# Altium schematic sheet Motorshield_ESP32_V4_schematic_outputjob.SchDoc
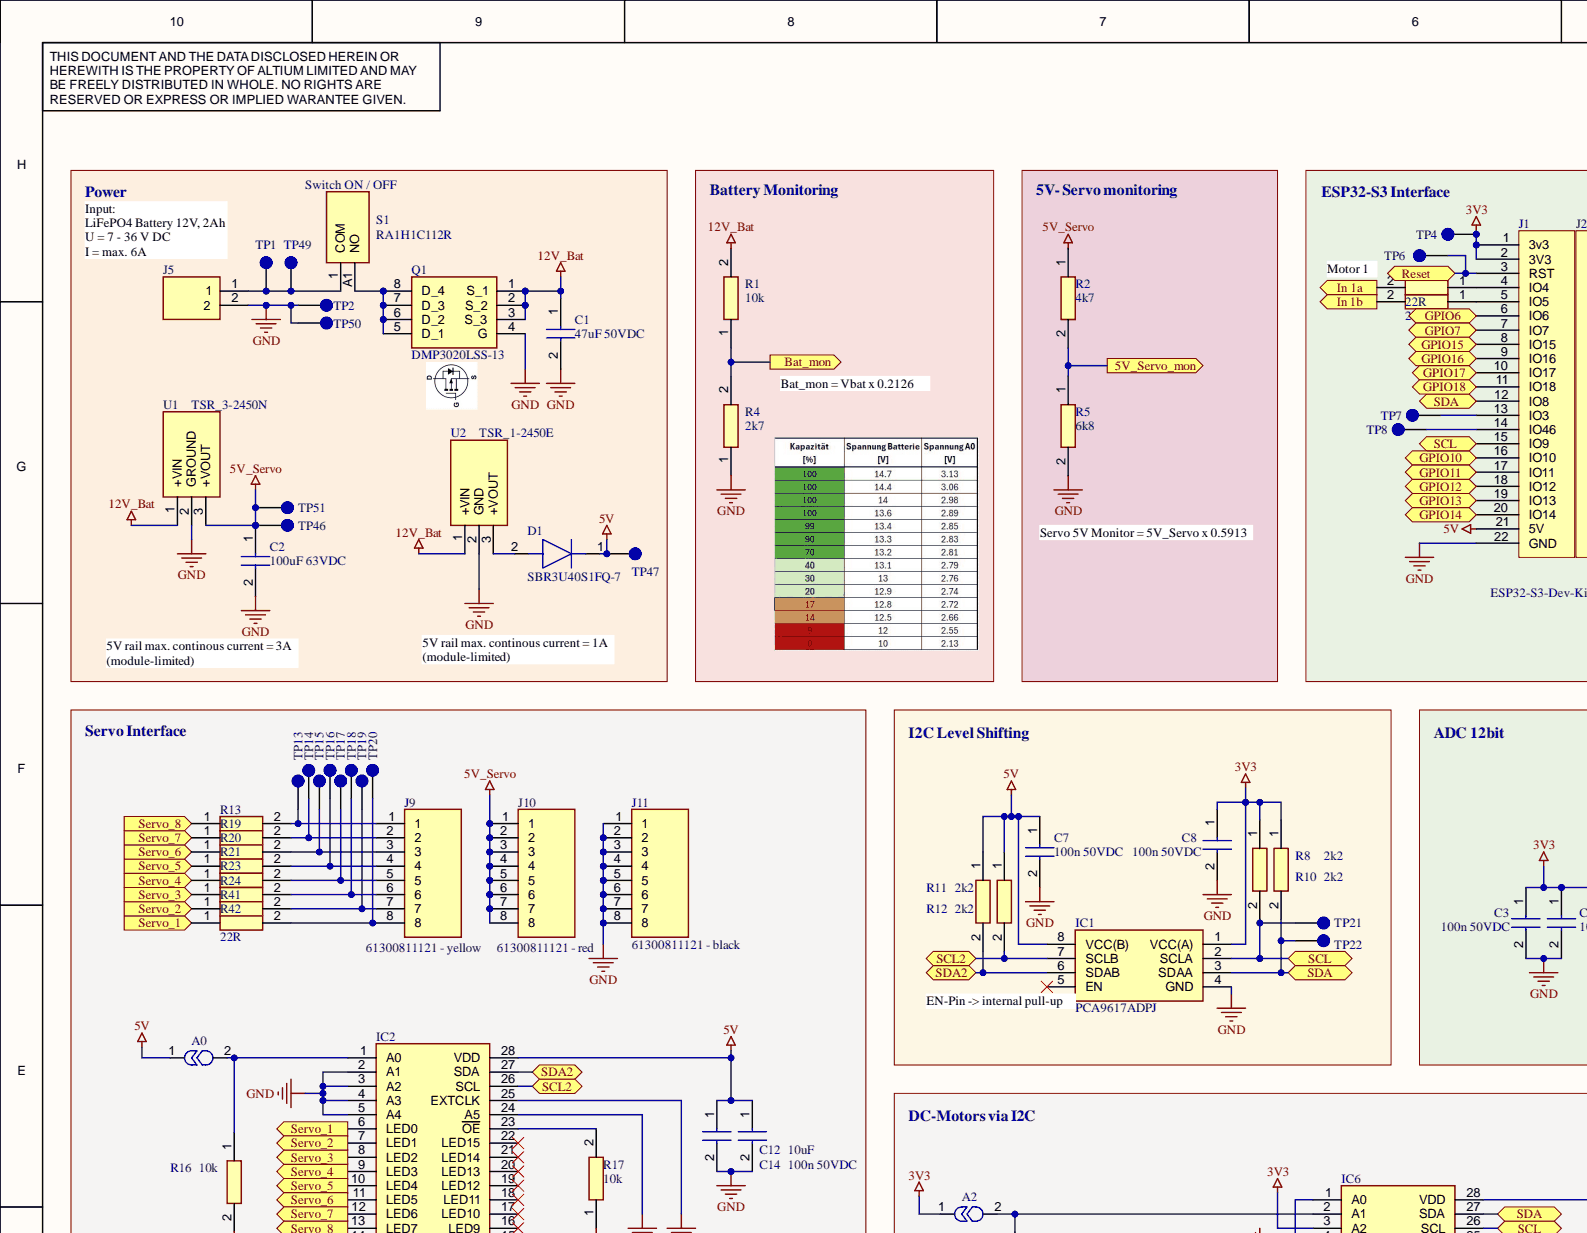
[diagram p0: Motorshield_ESP32_V4_schematic_outputjob.SchDoc, top left]
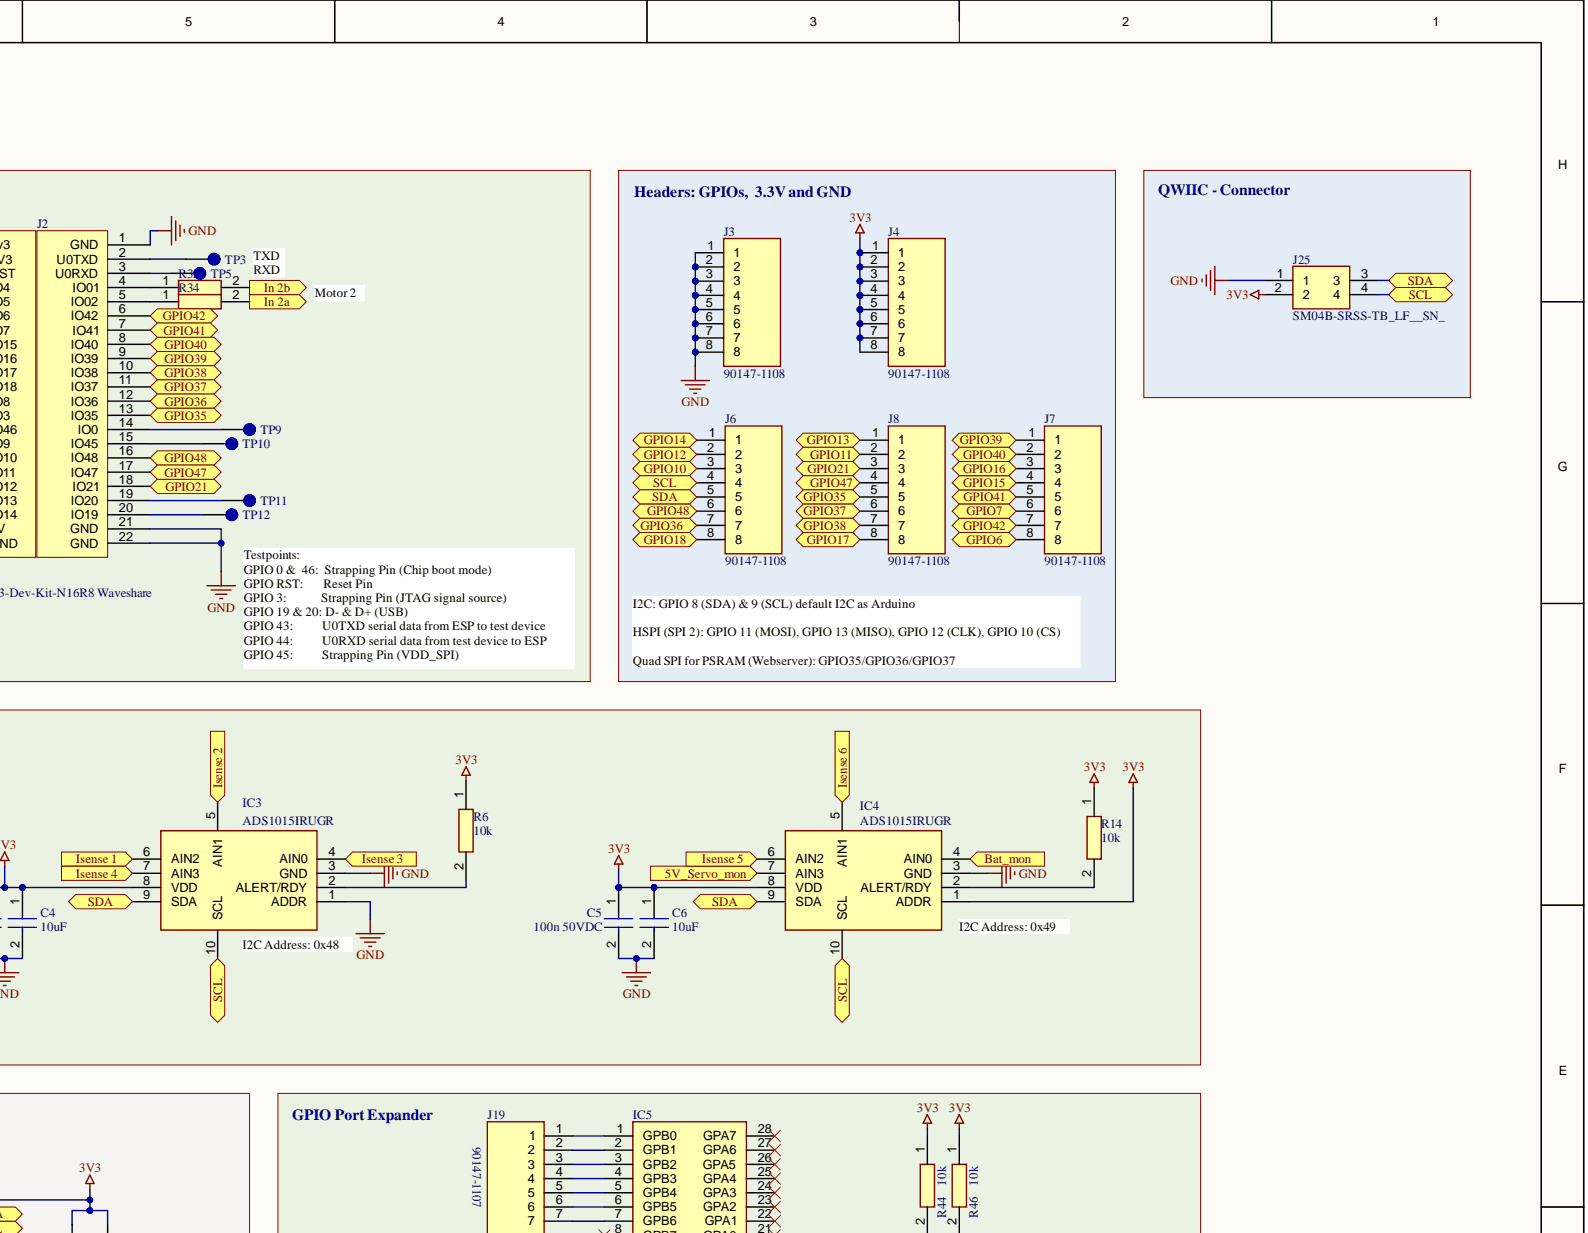
[diagram p1: Motorshield_ESP32_V4_schematic_outputjob.SchDoc, top right]
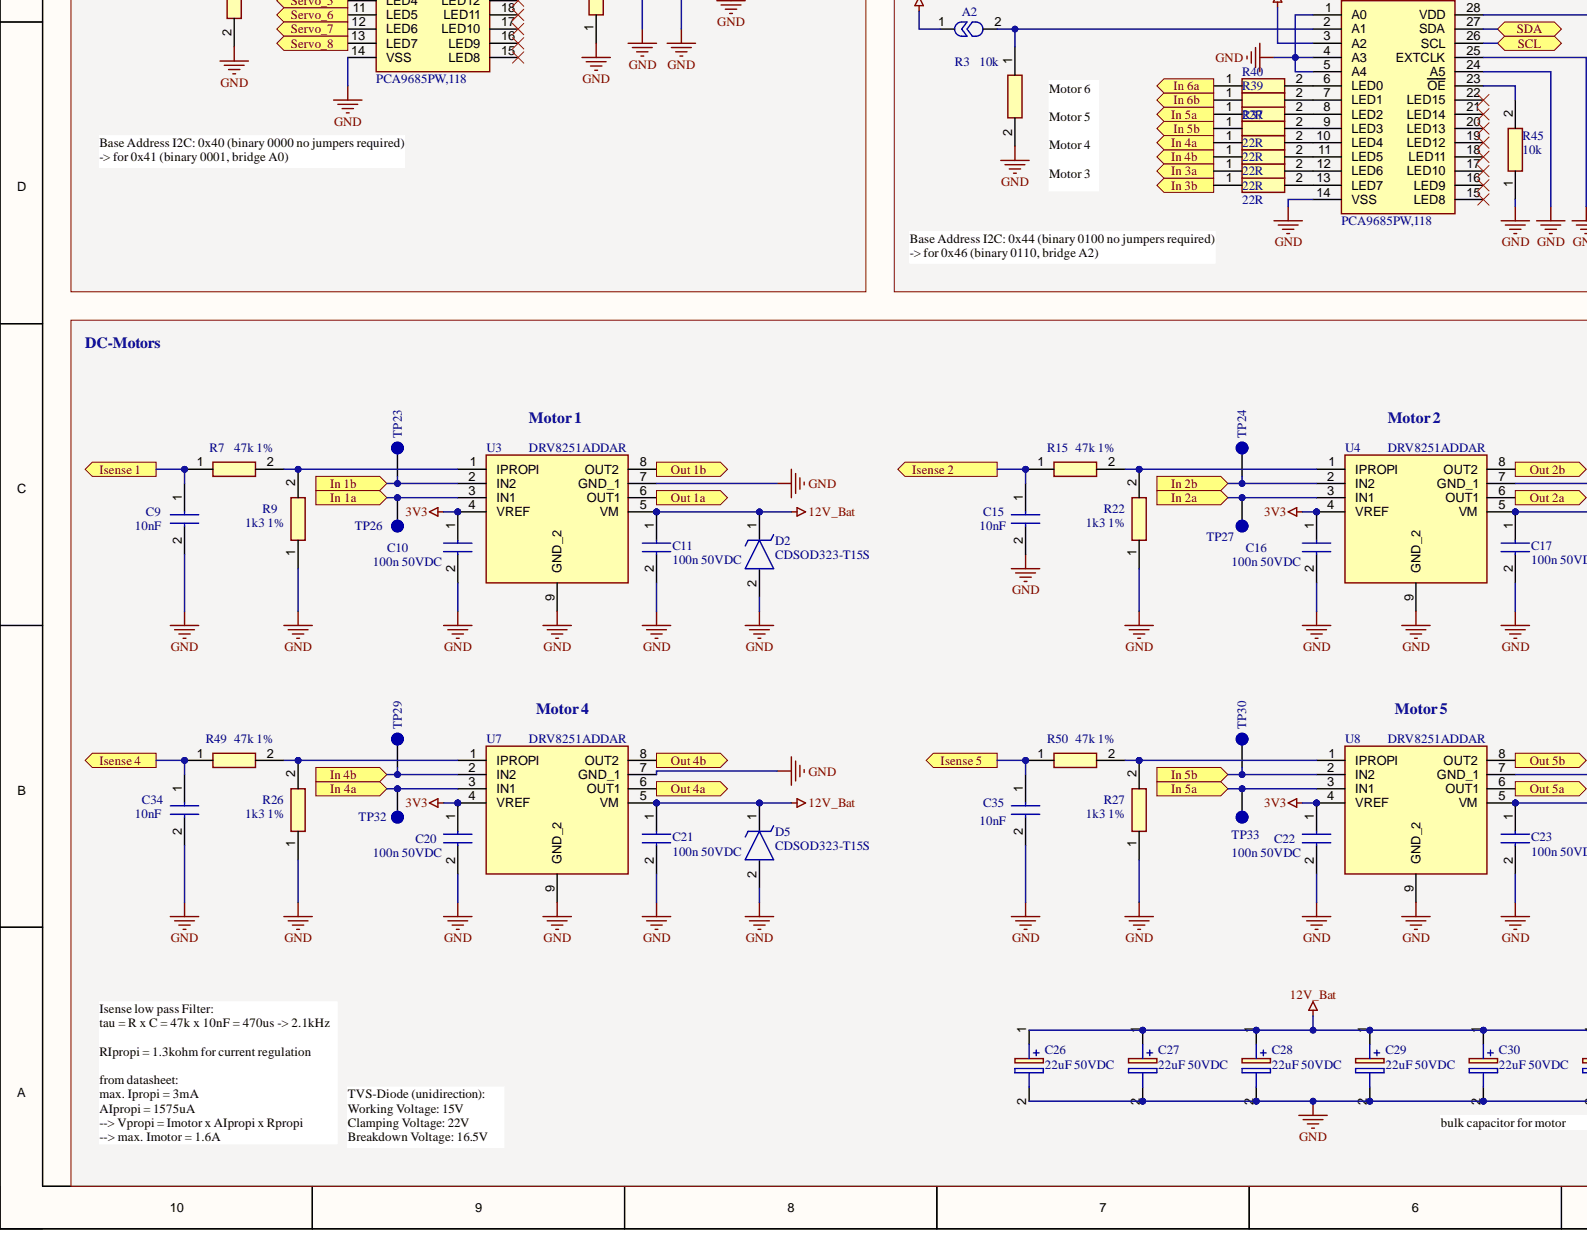
[diagram p2: Motorshield_ESP32_V4_schematic_outputjob.SchDoc, bottom left]
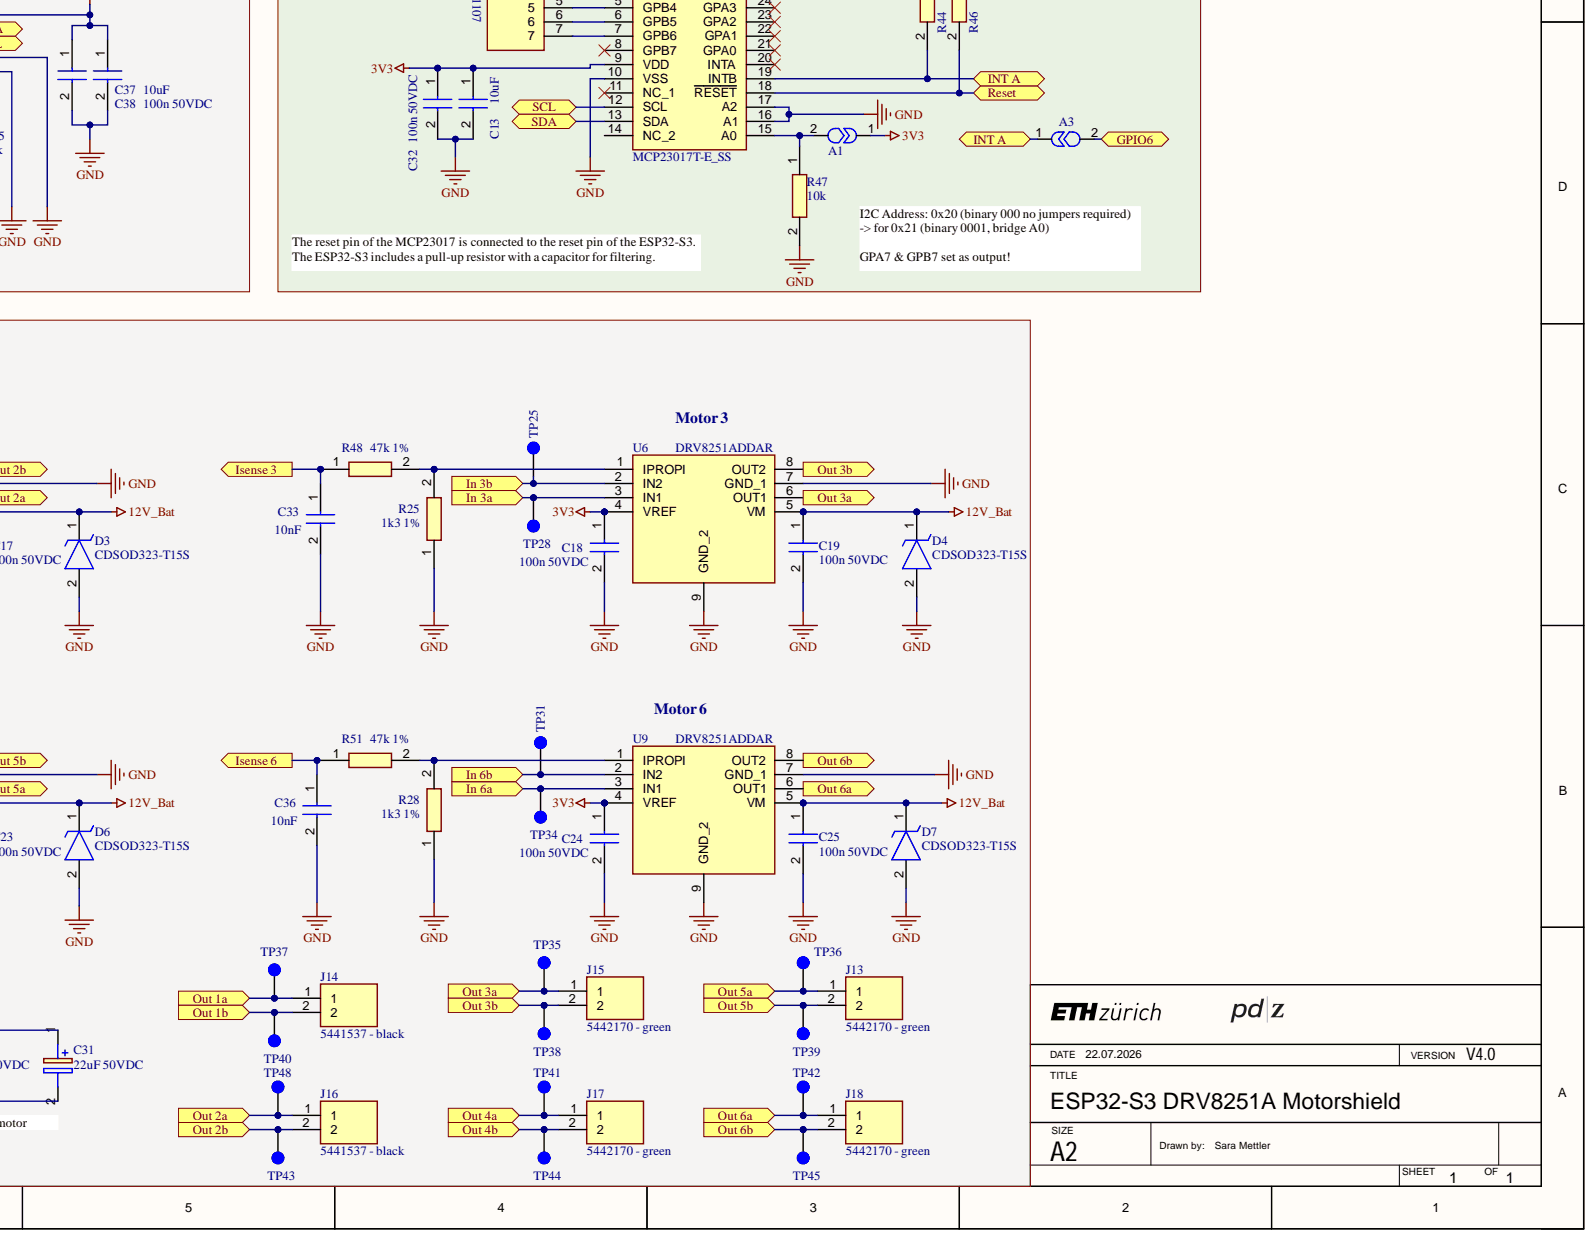
[diagram p3: Motorshield_ESP32_V4_schematic_outputjob.SchDoc, bottom right]

## Parts
A0  Solder Jumper  [JUMP_SOLDER_JUMPER_1206]  (value not drawn)
A1  Solder Jumper  [JUMP_SOLDER_JUMPER_1206]  (value not drawn)
A2  Solder Jumper  [JUMP_SOLDER_JUMPER_1206]  (value not drawn)
A3  Solder Jumper  [JUMP_SOLDER_JUMPER_1206]  (value not drawn)
C1  47uF 50VDC  [CAPAE660X980N]
C2  100uF 63VDC  [CAPAE830X1000N]
C3  100n 50VDC  [CAPC1608X90N]
C4  10uF  [GRM21BR60J226ME39L]
C5  100n 50VDC  [CAPC1608X90N]
C6  10uF  [GRM21BR60J226ME39L]
C7  100n 50VDC  [CAPC1608X90N]
C8  100n 50VDC  [CAPC1608X90N]
C9  10nF  [CAPC1608X90N]
C10  100n 50VDC  [CAPC1608X90N]
C11  100n 50VDC  [CAPC1608X90N]
C12  10uF  [GRM21BR60J226ME39L]
C13  10uF  [GRM21BR60J226ME39L]
C14  100n 50VDC  [CAPC1608X90N]
C15  10nF  [CAPC1608X90N]
C16  100n 50VDC  [CAPC1608X90N]
C17  100n 50VDC  [CAPC1608X90N]
C18  100n 50VDC  [CAPC1608X90N]
C19  100n 50VDC  [CAPC1608X90N]
C20  100n 50VDC  [CAPC1608X90N]
C21  100n 50VDC  [CAPC1608X90N]
C22  100n 50VDC  [CAPC1608X90N]
C23  100n 50VDC  [CAPC1608X90N]
C24  100n 50VDC  [CAPC1608X90N]
C25  100n 50VDC  [CAPC1608X90N]
C26  22uF 50VDC  [EEHZA1E101XP]
C27  22uF 50VDC  [EEHZA1E101XP]
C28  22uF 50VDC  [EEHZA1E101XP]
C29  22uF 50VDC  [EEHZA1E101XP]
C30  22uF 50VDC  [EEHZA1E101XP]
C31  22uF 50VDC  [EEHZA1E101XP]
C32  100n 50VDC  [CAPC1608X90N]
C33  10nF  [CAPC1608X90N]
C34  10nF  [CAPC1608X90N]
C35  10nF  [CAPC1608X90N]
C36  10nF  [CAPC1608X90N]
C37  10uF  [GRM21BR60J226ME39L]
C38  100n 50VDC  [CAPC1608X90N]
D1  SBR3U40S1FQ-7  [SODFL3518X115N]
D2  CDSOD323-T15S  [SOD2513X114N]
D3  CDSOD323-T15S  [SOD2513X114N]
D4  CDSOD323-T15S  [SOD2513X114N]
D5  CDSOD323-T15S  [SOD2513X114N]
D6  CDSOD323-T15S  [SOD2513X114N]
D7  CDSOD323-T15S  [SOD2513X114N]
IC1  PCA9617ADPJ  [SOP65P490X110-8N]
IC2  PCA9685PW,118  [SOP65P640X110-28N]
IC3  ADS1015IRUGR  [ADS1015IRUGR]
IC4  ADS1015IRUGR  [ADS1015IRUGR]
IC5  MCP23017T-E_SS  [SOP65P780X200-28N]
IC6  PCA9685PW,118  [SOP65P640X110-28N]
J1  Header links ESP32-S3  [CES12202SS]  (value not drawn)
J2  Header rechts ESP32-S3_1  [CES12202SS]  (value not drawn)
J3  90147-1108  [RHDR8W70P0X254_1X8_2017X244X870P]
J4  90147-1108  [RHDR8W70P0X254_1X8_2017X244X870P]
J5  691101710002 - blue  [RHDRRA2W95P0X500_1X2_1120X900X1260P]  (value not drawn)
J6  90147-1108  [RHDR8W70P0X254_1X8_2017X244X870P]
J7  90147-1108  [RHDR8W70P0X254_1X8_2017X244X870P]
J8  90147-1108  [RHDR8W70P0X254_1X8_2017X244X870P]
J9  61300811121 - yellow  [HDRV8W64P0X254_1X8_2032X254X854P]
J10  61300811121 - red  [HDRV8W64P0X254_1X8_2032X254X854P]
J11  61300811121 - black  [HDRV8W64P0X254_1X8_2032X254X854P]
J13  5442170 - green  [5442170]
J14  5441537 - black  [5441537]
J15  5442170 - green  [5442170]
J16  5441537 - black  [5441537]
J17  5442170 - green  [5442170]
J18  5442170 - green  [5442170]
J19  90147-1107  [SHDR7W65P0X254_1X7_2024X510X1180P]
J25  SM04B-SRSS-TB_LF__SN_  [SM04B-SRSS-TB(LFSN)]
Q1  DMP3020LSS-13  [SOIC127P600X175-8N]
R1  10k  [RESC1608X55N]
R2  4k7  [RESC1608X55N]
R3  10k  [RESC1608X55N]
R4  2k7  [RESC1608X55N]
R5  6k8  [RESC1608X55N]
R6  10k  [RESC1608X55N]
R7  47k 1%  [RESC1608X55N]
R8  2k2  [RESC1608X55N]
R9  1k3 1%  [RESC1608X55N]
R10  2k2  [RESC1608X55N]
R11  2k2  [RESC1608X55N]
R12  2k2  [RESC1608X55N]
R13  22R  [RESC1608X55N]
R14  10k  [RESC1608X55N]
R15  47k 1%  [RESC1608X55N]
R16  10k  [RESC1608X55N]
R17  10k  [RESC1608X55N]
R19  22R  [RESC1608X55N]
R20  22R  [RESC1608X55N]
R21  22R  [RESC1608X55N]
R22  1k3 1%  [RESC1608X55N]
R23  22R  [RESC1608X55N]
R24  22R  [RESC1608X55N]
R25  1k3 1%  [RESC1608X55N]
R26  1k3 1%  [RESC1608X55N]
R27  1k3 1%  [RESC1608X55N]
R28  1k3 1%  [RESC1608X55N]
R29  22R  [RESC1608X55N]
R30  22R  [RESC1608X55N]
R31  22R  [RESC1608X55N]
R32  22R  [RESC1608X55N]
R33  22R  [RESC1608X55N]
R34  22R  [RESC1608X55N]
R35  22R  [RESC1608X55N]
R36  22R  [RESC1608X55N]
R37  22R  [RESC1608X55N]
R38  22R  [RESC1608X55N]
R39  22R  [RESC1608X55N]
R40  22R  [RESC1608X55N]
R41  22R  [RESC1608X55N]
R42  22R  [RESC1608X55N]
R44  10k  [RESC1608X55N]
R45  10k  [RESC1608X55N]
R46  10k  [RESC1608X55N]
R47  10k  [RESC1608X55N]
R48  47k 1%  [RESC1608X55N]
R49  47k 1%  [RESC1608X55N]
R50  47k 1%  [RESC1608X55N]
R51  47k 1%  [RESC1608X55N]
S1  RA1H1C112R  [RA1H1C112R]
TP1  837-22-001-30-001101  [8372200130001101]  (value not drawn)
TP2  837-22-001-30-001101  [8372200130001101]  (value not drawn)
TP3  837-22-001-30-001101  [8372200130001101]  (value not drawn)
TP4  837-22-001-30-001101  [8372200130001101]  (value not drawn)
TP5  837-22-001-30-001101  [8372200130001101]  (value not drawn)
TP6  837-22-001-30-001101  [8372200130001101]  (value not drawn)
TP7  837-22-001-30-001101  [8372200130001101]  (value not drawn)
TP8  837-22-001-30-001101  [8372200130001101]  (value not drawn)
TP9  837-22-001-30-001101  [8372200130001101]  (value not drawn)
TP10  837-22-001-30-001101  [8372200130001101]  (value not drawn)
TP11  837-22-001-30-001101  [8372200130001101]  (value not drawn)
TP12  837-22-001-30-001101  [8372200130001101]  (value not drawn)
TP13  837-22-001-30-001101  [8372200130001101]  (value not drawn)
TP14  837-22-001-30-001101  [8372200130001101]  (value not drawn)
TP15  837-22-001-30-001101  [8372200130001101]  (value not drawn)
TP16  837-22-001-30-001101  [8372200130001101]  (value not drawn)
TP17  837-22-001-30-001101  [8372200130001101]  (value not drawn)
TP18  837-22-001-30-001101  [8372200130001101]  (value not drawn)
TP19  837-22-001-30-001101  [8372200130001101]  (value not drawn)
TP20  837-22-001-30-001101  [8372200130001101]  (value not drawn)
TP21  837-22-001-30-001101  [8372200130001101]  (value not drawn)
TP22  837-22-001-30-001101  [8372200130001101]  (value not drawn)
TP23  837-22-001-30-001101  [8372200130001101]  (value not drawn)
TP24  837-22-001-30-001101  [8372200130001101]  (value not drawn)
TP25  837-22-001-30-001101  [8372200130001101]  (value not drawn)
TP26  837-22-001-30-001101  [8372200130001101]  (value not drawn)
TP27  837-22-001-30-001101  [8372200130001101]  (value not drawn)
TP28  837-22-001-30-001101  [8372200130001101]  (value not drawn)
TP29  837-22-001-30-001101  [8372200130001101]  (value not drawn)
TP30  837-22-001-30-001101  [8372200130001101]  (value not drawn)
TP31  837-22-001-30-001101  [8372200130001101]  (value not drawn)
TP32  837-22-001-30-001101  [8372200130001101]  (value not drawn)
TP33  837-22-001-30-001101  [8372200130001101]  (value not drawn)
TP34  837-22-001-30-001101  [8372200130001101]  (value not drawn)
TP35  837-22-001-30-001101  [8372200130001101]  (value not drawn)
TP36  837-22-001-30-001101  [8372200130001101]  (value not drawn)
TP37  837-22-001-30-001101  [8372200130001101]  (value not drawn)
TP38  837-22-001-30-001101  [8372200130001101]  (value not drawn)
TP39  837-22-001-30-001101  [8372200130001101]  (value not drawn)
TP40  837-22-001-30-001101  [8372200130001101]  (value not drawn)
TP41  837-22-001-30-001101  [8372200130001101]  (value not drawn)
TP42  837-22-001-30-001101  [8372200130001101]  (value not drawn)
TP43  837-22-001-30-001101  [8372200130001101]  (value not drawn)
TP44  837-22-001-30-001101  [8372200130001101]  (value not drawn)
TP45  837-22-001-30-001101  [8372200130001101]  (value not drawn)
TP46  837-22-001-30-001101  [8372200130001101]  (value not drawn)
TP47  837-22-001-30-001101  [8372200130001101]  (value not drawn)
TP48  837-22-001-30-001101  [8372200130001101]  (value not drawn)
TP49  837-22-001-30-001101  [8372200130001101]  (value not drawn)
TP50  837-22-001-30-001101  [8372200130001101]  (value not drawn)
TP51  837-22-001-30-001101  [8372200130001101]  (value not drawn)
U1  TSR_3-2450N  [TSR32450N]
U2  TSR_1-2450E  [TSR12450E]
U3  DRV8251ADDAR  [SOIC127P600X170-9N]
U4  DRV8251ADDAR  [SOIC127P600X170-9N]
U6  DRV8251ADDAR  [SOIC127P600X170-9N]
U7  DRV8251ADDAR  [SOIC127P600X170-9N]
U8  DRV8251ADDAR  [SOIC127P600X170-9N]
U9  DRV8251ADDAR  [SOIC127P600X170-9N]

## Ports
5V_Servo_mon, Bat_mon, GPIO6, GPIO7, GPIO10, GPIO11, GPIO12, GPIO13, GPIO14, GPIO15, GPIO16, GPIO17, GPIO18, GPIO21, GPIO35, GPIO36, GPIO37, GPIO38, GPIO39, GPIO40, GPIO41, GPIO42, GPIO47, GPIO48, In 1a, In 1b, In 2a, In 2b, In 3a, In 3b, In 4a, In 4b, In 5a, In 5b, In 6a, In 6b, INT A, Isense 1, Isense 2, Isense 3, Isense 4, Isense 5, Isense 6, Out 1a, Out 1b, Out 2a, Out 2b, Out 3a, Out 3b, Out 4a, Out 4b, Out 5a, Out 5b, Out 6a, Out 6b, Reset, SCL, SCL2, SDA, SDA2, Servo_1, Servo_2, Servo_3, Servo_4, Servo_5, Servo_6, Servo_7, Servo_8

## Nets
3V3: A1.1 A2.1 C3.1 C4.1 C5.1 C6.1 C8.1 C10.1 C13.1 C16.1 C18.1 C20.1 C22.1 C24.1 C32.1 C37.1 C38.1 IC1.1(VCC(A)) IC3.8(VDD) IC4.1(ADDR) IC4.8(VDD) IC5.9(VDD) IC6.3(A2) IC6.28(VDD) J1.1(3v3) J1.2(3V3) J4.1 J4.2 J4.3 J4.4 J4.5 J4.6 J4.7 J4.8 J25.2 R6.1 R8.1 R10.1 R14.1 R44.1 R46.1 TP4.1 U3.4(VREF) U4.4(VREF) U6.4(VREF) U7.4(VREF) U8.4(VREF) U9.4(VREF)
5V: A0.1 C7.1 C12.1 C14.1 D1.1 IC1.8(VCC(B)) IC2.28(VDD) J1.21(5V) R11.1 R12.1 TP47.1
5V_Servo: C2.1 J10.1 J10.2 J10.3 J10.4 J10.5 J10.6 J10.7 J10.8 R2.1 TP46.1 TP51.1 U1.3
12V_Bat: C1.1 C11.1 C17.1 C19.1 C21.1 C23.1 C25.1 C26.1 C27.1 C28.1 C29.1 C30.1 C31.1 D2.1 D3.1 D4.1 D5.1 D6.1 D7.1 Q1.1(S_1) Q1.2(S_2) Q1.3(S_3) R1.2 U1.1 U2.1 U3.5(VM) U4.5(VM) U6.5(VM) U7.5(VM) U8.5(VM) U9.5(VM)
GND: C1.2 C2.2 C3.2 C4.2 C5.2 C6.2 C7.2 C8.2 C9.2 C10.2 C11.2 C12.2 C13.2 C14.2 C15.2 C16.2 C17.2 C18.2 C19.2 C20.2 C21.2 C22.2 C23.2 C24.2 C25.2 C26.2 C27.2 C28.2 C29.2 C30.2 C31.2 C32.2 C33.2 C34.2 C35.2 C36.2 C37.2 C38.2 D2.2 D3.2 D4.2 D5.2 D6.2 D7.2 IC1.4(GND) IC2.2(A1) IC2.3(A2) IC2.4(A3) IC2.5(A4) IC2.14(VSS) IC2.24(A5) IC2.25(EXTCLK) IC3.1(ADDR) IC3.3(GND) IC4.3(GND) IC5.10(VSS) IC5.16(A1) IC5.17(A2) IC6.1(A0) IC6.4(A3) IC6.5(A4) IC6.14(VSS) IC6.24(A5) IC6.25(EXTCLK) J1.22(GND) J2.1(GND) J2.21(GND) J2.22(GND) J3.1 J3.2 J3.3 J3.4 J3.5 J3.6 J3.7 J3.8 J5.2 J11.1 J11.2 J11.3 J11.4 J11.5 J11.6 J11.7 J11.8 J25.1 Q1.4(G) R3.2 R4.1 R5.2 R9.1 R16.2 R17.1 R22.1 R25.1 R26.1 R27.1 R28.1 R45.1 R47.2 TP2.1 TP50.1 U1.2 U2.2 U3.7(GND_1) U3.9(GND_2) U4.7(GND_1) U4.9(GND_2) U6.7(GND_1) U6.9(GND_2) U7.7(GND_1) U7.9(GND_2) U8.7(GND_1) U8.9(GND_2) U9.7(GND_1) U9.9(GND_2)
5V_Servo_mon: IC4.7(AIN3) R2.2 R5.1 ; port 5V_Servo_mon
Bat_mon: IC4.4(AIN0) R1.1 R4.2 ; port Bat_mon
GPIO6: A3.2 J1.6(IO6) J7.8 ; port GPIO6
GPIO7: J1.7(IO7) J7.6 ; port GPIO7
GPIO10: J1.16(IO10) J6.3 ; port GPIO10
GPIO11: J1.17(IO11) J8.2 ; port GPIO11
GPIO12: J1.18(IO12) J6.2 ; port GPIO12
GPIO13: J1.19(IO13) J8.1 ; port GPIO13
GPIO14: J1.20(IO14) J6.1 ; port GPIO14
GPIO15: J1.8(IO15) J7.4 ; port GPIO15
GPIO16: J1.9(IO16) J7.3 ; port GPIO16
GPIO17: J1.10(IO17) J8.8 ; port GPIO17
GPIO18: J1.11(IO18) J6.8 ; port GPIO18
GPIO21: J2.18(IO21) J8.3 ; port GPIO21
GPIO35: J2.13(IO35) J8.5 ; port GPIO35
GPIO36: J2.12(IO36) J6.7 ; port GPIO36
GPIO37: J2.11(IO37) J8.6 ; port GPIO37
GPIO38: J2.10(IO38) J8.7 ; port GPIO38
GPIO39: J2.9(IO39) J7.1 ; port GPIO39
GPIO40: J2.8(IO40) J7.2 ; port GPIO40
GPIO41: J2.7(IO41) J7.5 ; port GPIO41
GPIO42: J2.6(IO42) J7.7 ; port GPIO42
GPIO47: J2.17(IO47) J8.4 ; port GPIO47
GPIO48: J2.16(IO48) J6.6 ; port GPIO48
In 1a: R29.2 TP26.1 U3.3(IN1) ; port In 1a
In 1b: R30.2 TP23.1 U3.2(IN2) ; port In 1b
In 2a: R34.2 TP27.1 U4.3(IN1) ; port In 2a
In 2b: R32.2 TP24.1 U4.2(IN2) ; port In 2b
In 3a: R33.1 TP28.1 U6.3(IN1) ; port In 3a
In 3b: R31.1 TP25.1 U6.2(IN2) ; port In 3b
In 4a: R36.1 TP32.1 U7.3(IN1) ; port In 4a
In 4b: R35.1 TP29.1 U7.2(IN2) ; port In 4b
In 5a: R38.1 TP33.1 U8.3(IN1) ; port In 5a
In 5b: R37.1 TP30.1 U8.2(IN2) ; port In 5b
In 6a: R40.1 TP34.1 U9.3(IN1) ; port In 6a
In 6b: R39.1 TP31.1 U9.2(IN2) ; port In 6b
INT A: A3.1 IC5.19(INTB) R44.2 ; port INT A
Isense 1: C9.1 IC3.6(AIN2) R7.1 ; port Isense 1
Isense 2: C15.1 IC3.5(AIN1) R15.1 ; port Isense 2
Isense 3: C33.1 IC3.4(AIN0) R48.1 ; port Isense 3
Isense 4: C34.1 IC3.7(AIN3) R49.1 ; port Isense 4
Isense 5: C35.1 IC4.6(AIN2) R50.1 ; port Isense 5
Isense 6: C36.1 IC4.5(AIN1) R51.1 ; port Isense 6
Out 1a: J14.1 TP37.1 U3.6(OUT1) ; port Out 1a
Out 1b: J14.2 TP40.1 U3.8(OUT2) ; port Out 1b
Out 2a: J16.1 TP48.1 U4.6(OUT1) ; port Out 2a
Out 2b: J16.2 TP43.1 U4.8(OUT2) ; port Out 2b
Out 3a: J15.1 TP35.1 U6.6(OUT1) ; port Out 3a
Out 3b: J15.2 TP38.1 U6.8(OUT2) ; port Out 3b
Out 4a: J17.1 TP41.1 U7.6(OUT1) ; port Out 4a
Out 4b: J17.2 TP44.1 U7.8(OUT2) ; port Out 4b
Out 5a: J13.1 TP36.1 U8.6(OUT1) ; port Out 5a
Out 5b: J13.2 TP39.1 U8.8(OUT2) ; port Out 5b
Out 6a: J18.1 TP42.1 U9.6(OUT1) ; port Out 6a
Out 6b: J18.2 TP45.1 U9.8(OUT2) ; port Out 6b
Reset: IC5.18(RESET) J1.3(RST) R46.2 TP6.1 ; port Reset
SCL: IC1.2(SCLA) IC3.10(SCL) IC4.10(SCL) IC5.12(SCL) IC6.26(SCL) J1.15(IO9) J6.4 J25.4 R8.2 TP21.1 ; port SCL
SCL2: IC1.7(SCLB) IC2.26(SCL) R12.2 ; port SCL2
SDA: IC1.3(SDAA) IC3.9(SDA) IC4.9(SDA) IC5.13(SDA) IC6.27(SDA) J1.12(IO8) J6.5 J25.3 R10.2 TP22.1 ; port SDA
SDA2: IC1.6(SDAB) IC2.27(SDA) R11.2 ; port SDA2
Servo_1: IC2.6(LED0) R42.1 ; port Servo_1
Servo_2: IC2.7(LED1) R41.1 ; port Servo_2
Servo_3: IC2.8(LED2) R24.1 ; port Servo_3
Servo_4: IC2.9(LED3) R23.1 ; port Servo_4
Servo_5: IC2.10(LED4) R21.1 ; port Servo_5
Servo_6: IC2.11(LED5) R20.1 ; port Servo_6
Servo_7: IC2.12(LED6) R19.1 ; port Servo_7
Servo_8: IC2.13(LED7) R13.1 ; port Servo_8
NetA0_2: A0.2 IC2.1(A0) R16.1
NetA1_2: A1.2 IC5.15(A0) R47.1
NetA2_2: A2.2 IC6.2(A1) R3.1
NetD1_2: D1.2 U2.3
NetIC2_23: IC2.23(OE) R17.2
NetIC3_2: IC3.2(ALERT/RDY) R6.2
NetIC4_2: IC4.2(ALERT/RDY) R14.2
NetIC5_1: IC5.1(GPB0) J19.1
NetIC5_2: IC5.2(GPB1) J19.2
NetIC5_3: IC5.3(GPB2) J19.3
NetIC5_4: IC5.4(GPB3) J19.4
NetIC5_5: IC5.5(GPB4) J19.5
NetIC5_6: IC5.6(GPB5) J19.6
NetIC5_7: IC5.7(GPB6) J19.7
NetIC6_6: IC6.6(LED0) R40.2
NetIC6_7: IC6.7(LED1) R39.2
NetIC6_8: IC6.8(LED2) R38.2
NetIC6_9: IC6.9(LED3) R37.2
NetIC6_10: IC6.10(LED4) R36.2
NetIC6_11: IC6.11(LED5) R35.2
NetIC6_12: IC6.12(LED6) R33.2
NetIC6_13: IC6.13(LED7) R31.2
NetIC6_23: IC6.23(OE) R45.2
NetJ1_4: J1.4(IO4) R29.1
NetJ1_5: J1.5(IO5) R30.1
NetJ1_13: J1.13(IO3) TP7.1
NetJ1_14: J1.14(IO46) TP8.1
NetJ2_2: J2.2(U0TXD) TP3.1
NetJ2_3: J2.3(U0RXD) TP5.1
NetJ2_4: J2.4(IO01) R32.1
NetJ2_5: J2.5(IO02) R34.1
NetJ2_14: J2.14(IO0) TP9.1
NetJ2_15: J2.15(IO45) TP10.1
NetJ2_19: J2.19(IO20) TP11.1
NetJ2_20: J2.20(IO19) TP12.1
NetJ5_1: J5.1 S1.1 TP1.1 TP49.1
NetJ9_1: J9.1 R13.2 TP13.1
NetJ9_2: J9.2 R19.2 TP14.1
NetJ9_3: J9.3 R20.2 TP15.1
NetJ9_4: J9.4 R21.2 TP16.1
NetJ9_5: J9.5 R23.2 TP17.1
NetJ9_6: J9.6 R24.2 TP18.1
NetJ9_7: J9.7 R41.2 TP19.1
NetJ9_8: J9.8 R42.2 TP20.1
NetQ1_5: Q1.5(D_1) Q1.6(D_2) Q1.7(D_3) Q1.8(D_4) S1.A1
NetR7_2: R7.2 R9.2 U3.1(IPROPI)
NetR15_2: R15.2 R22.2 U4.1(IPROPI)
NetR25_2: R25.2 R48.2 U6.1(IPROPI)
NetR26_2: R26.2 R49.2 U7.1(IPROPI)
NetR27_2: R27.2 R50.2 U8.1(IPROPI)
NetR28_2: R28.2 R51.2 U9.1(IPROPI)

Not connected: IC1 5(EN); IC2 15(LED8) 16(LED9) 17(LED10) 18(LED11) 19(LED12) 20(LED13) 21(LED14) 22(LED15); IC5 8(GPB7) 11(NC_1) 14(NC_2) 20(INTA) 21(GPA0) 22(GPA1) 23(GPA2) 24(GPA3) 25(GPA4) 26(GPA5) 27(GPA6) 28(GPA7); IC6 15(LED8) 16(LED9) 17(LED10) 18(LED11) 19(LED12) 20(LED13) 21(LED14) 22(LED15)
